# Altium schematic sheet DAQ.SchDoc(DAQ)
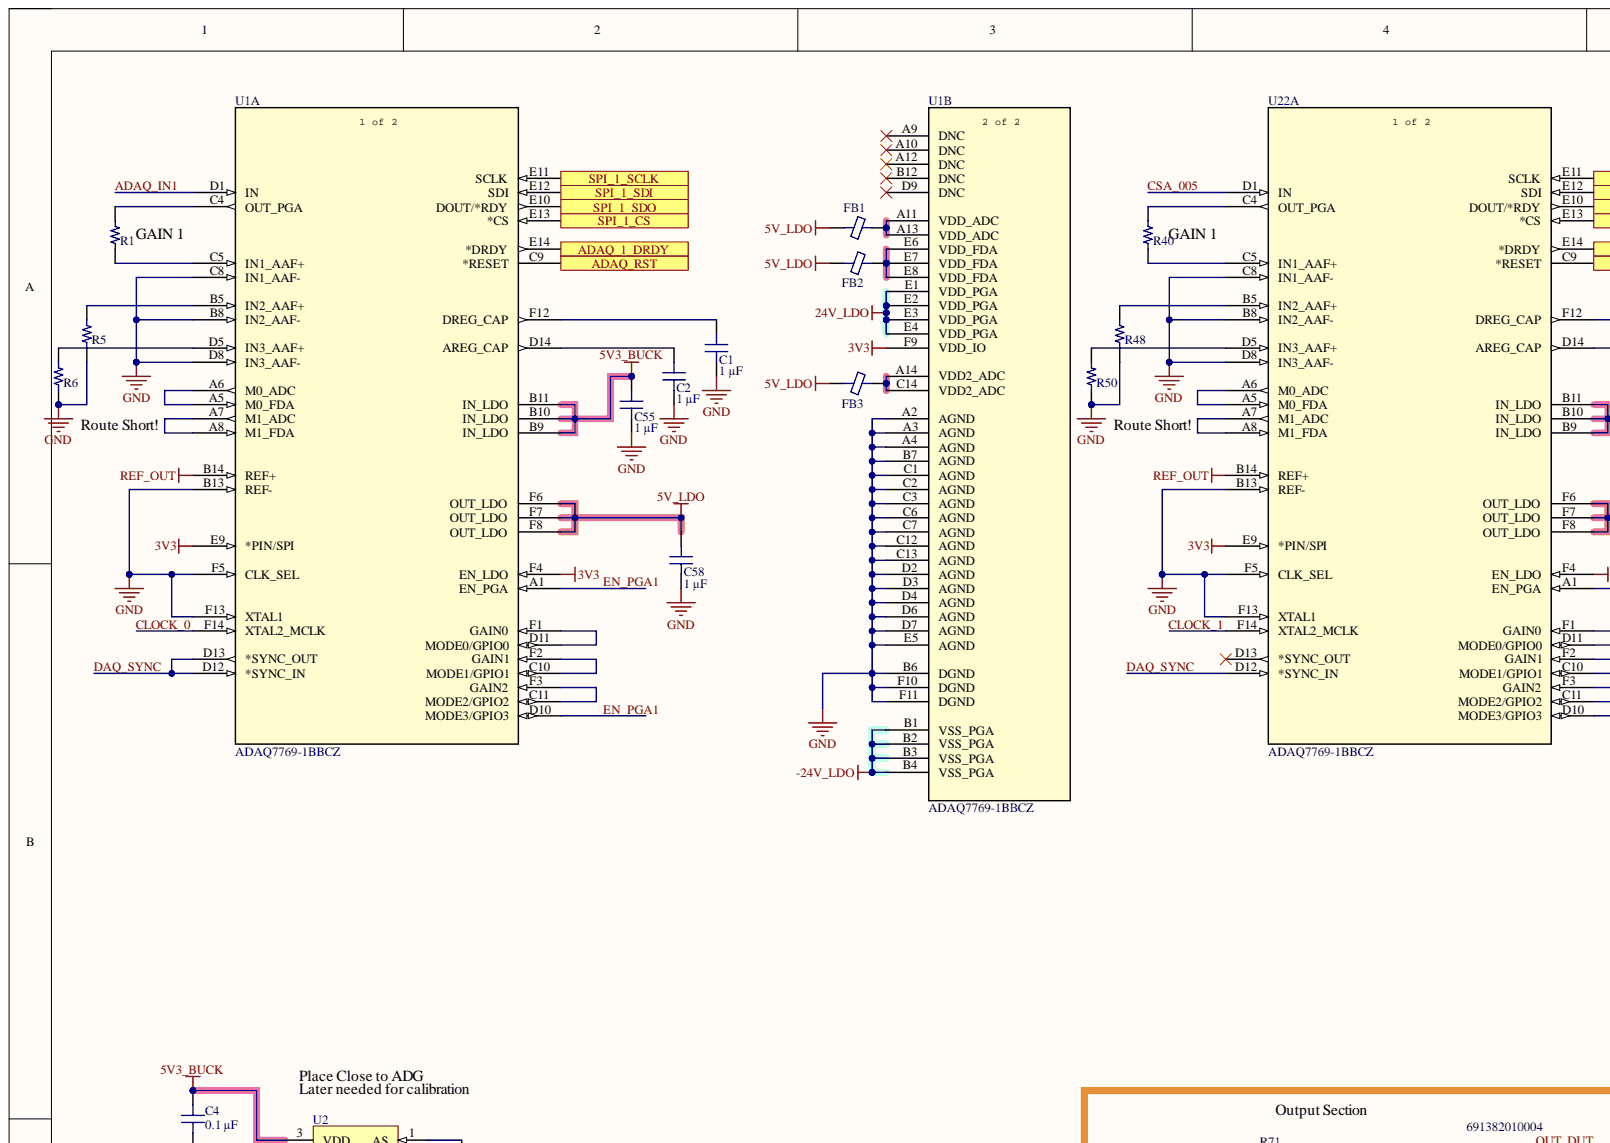
[diagram p0: DAQ.SchDoc, top left]
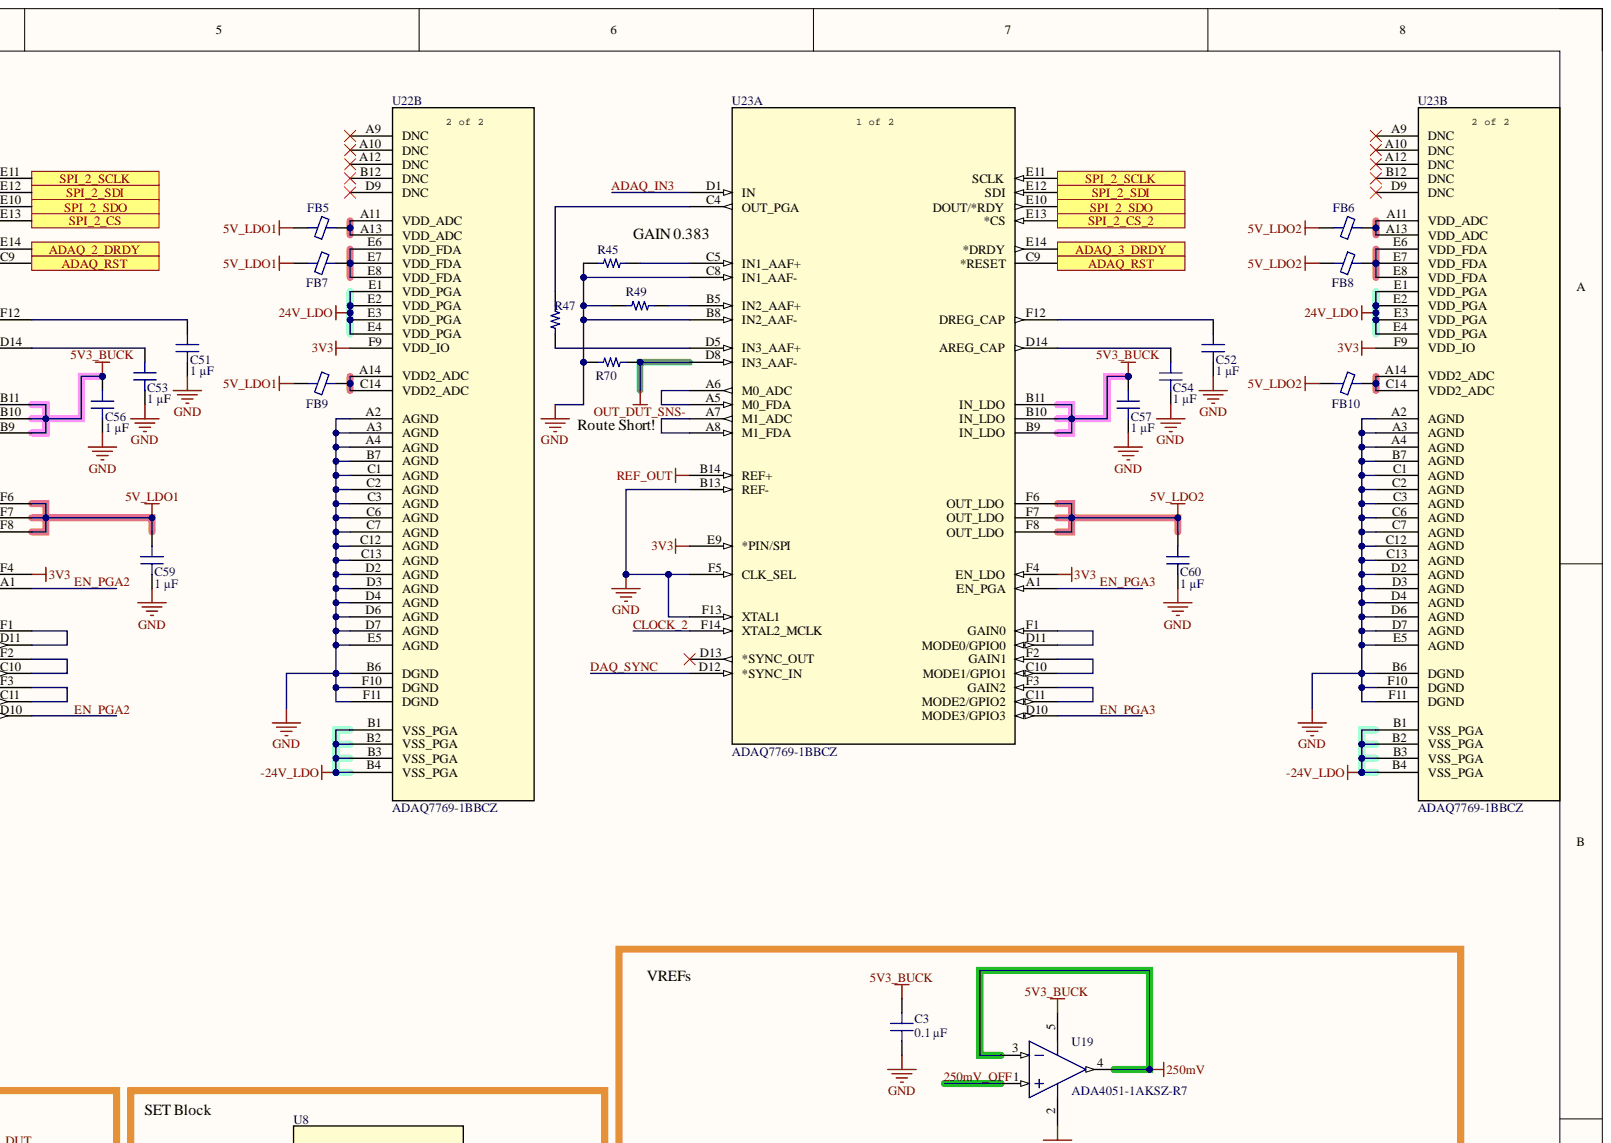
[diagram p1: DAQ.SchDoc, top right]
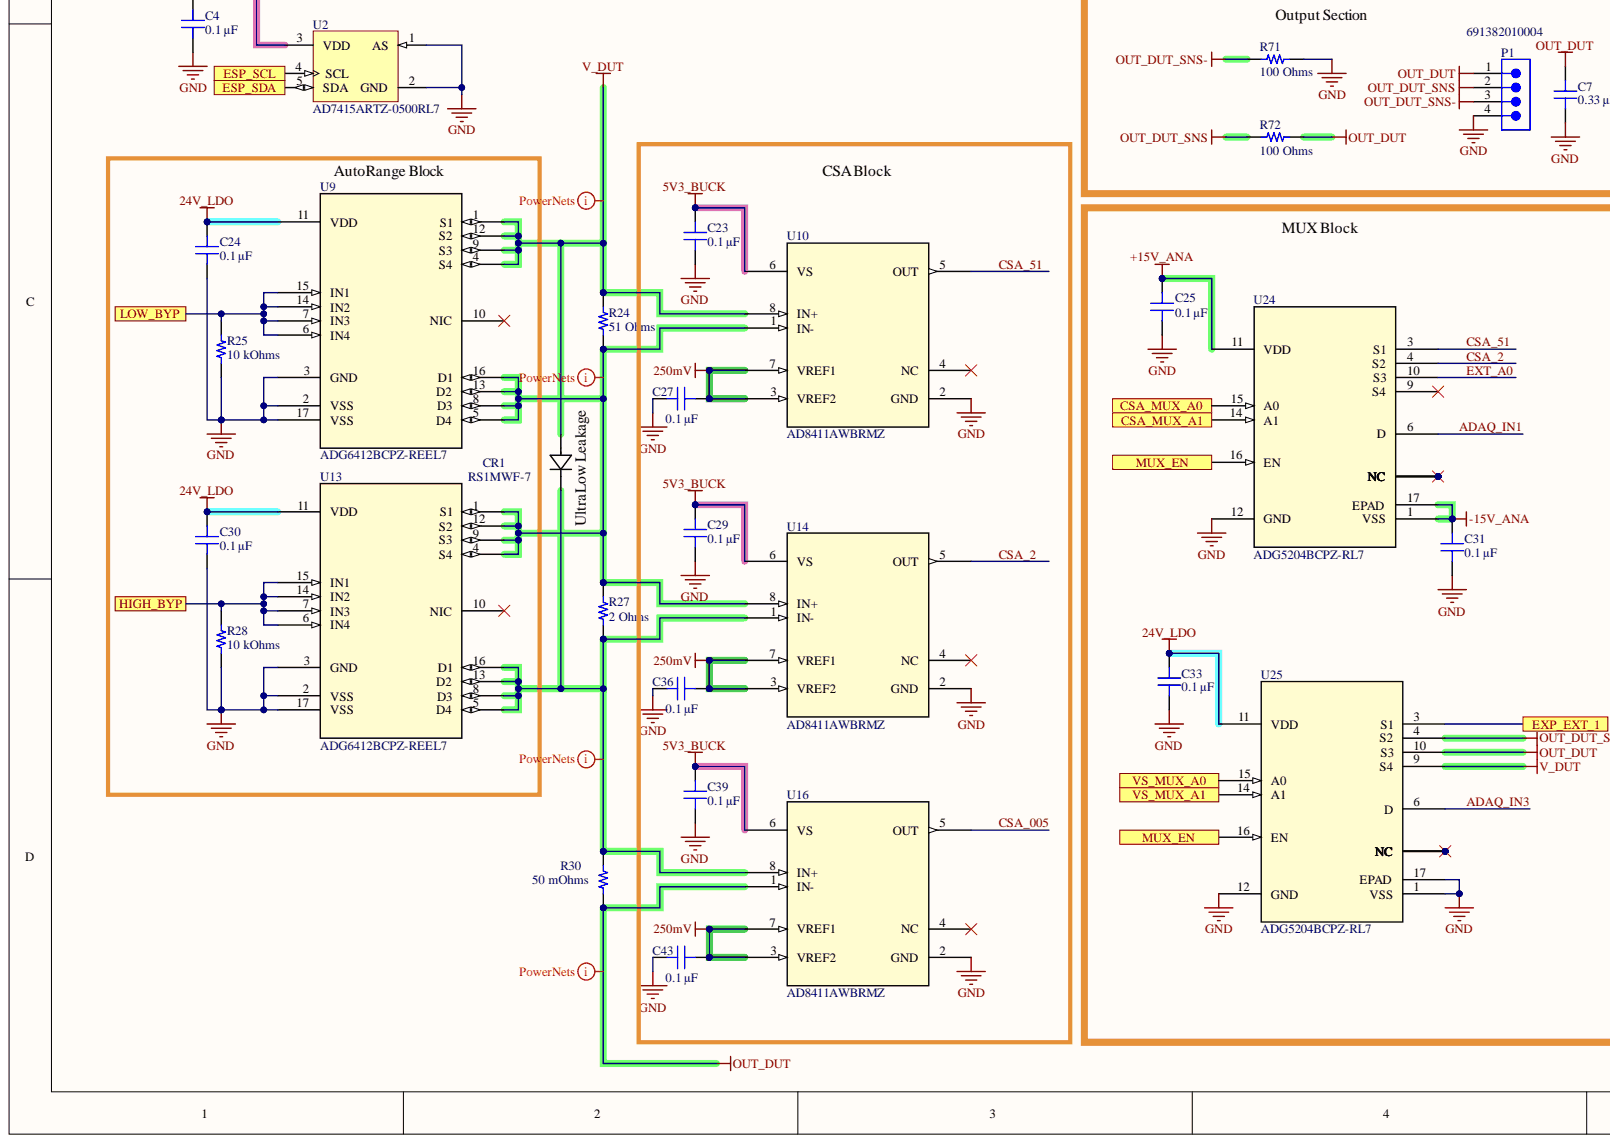
[diagram p2: DAQ.SchDoc, bottom left]
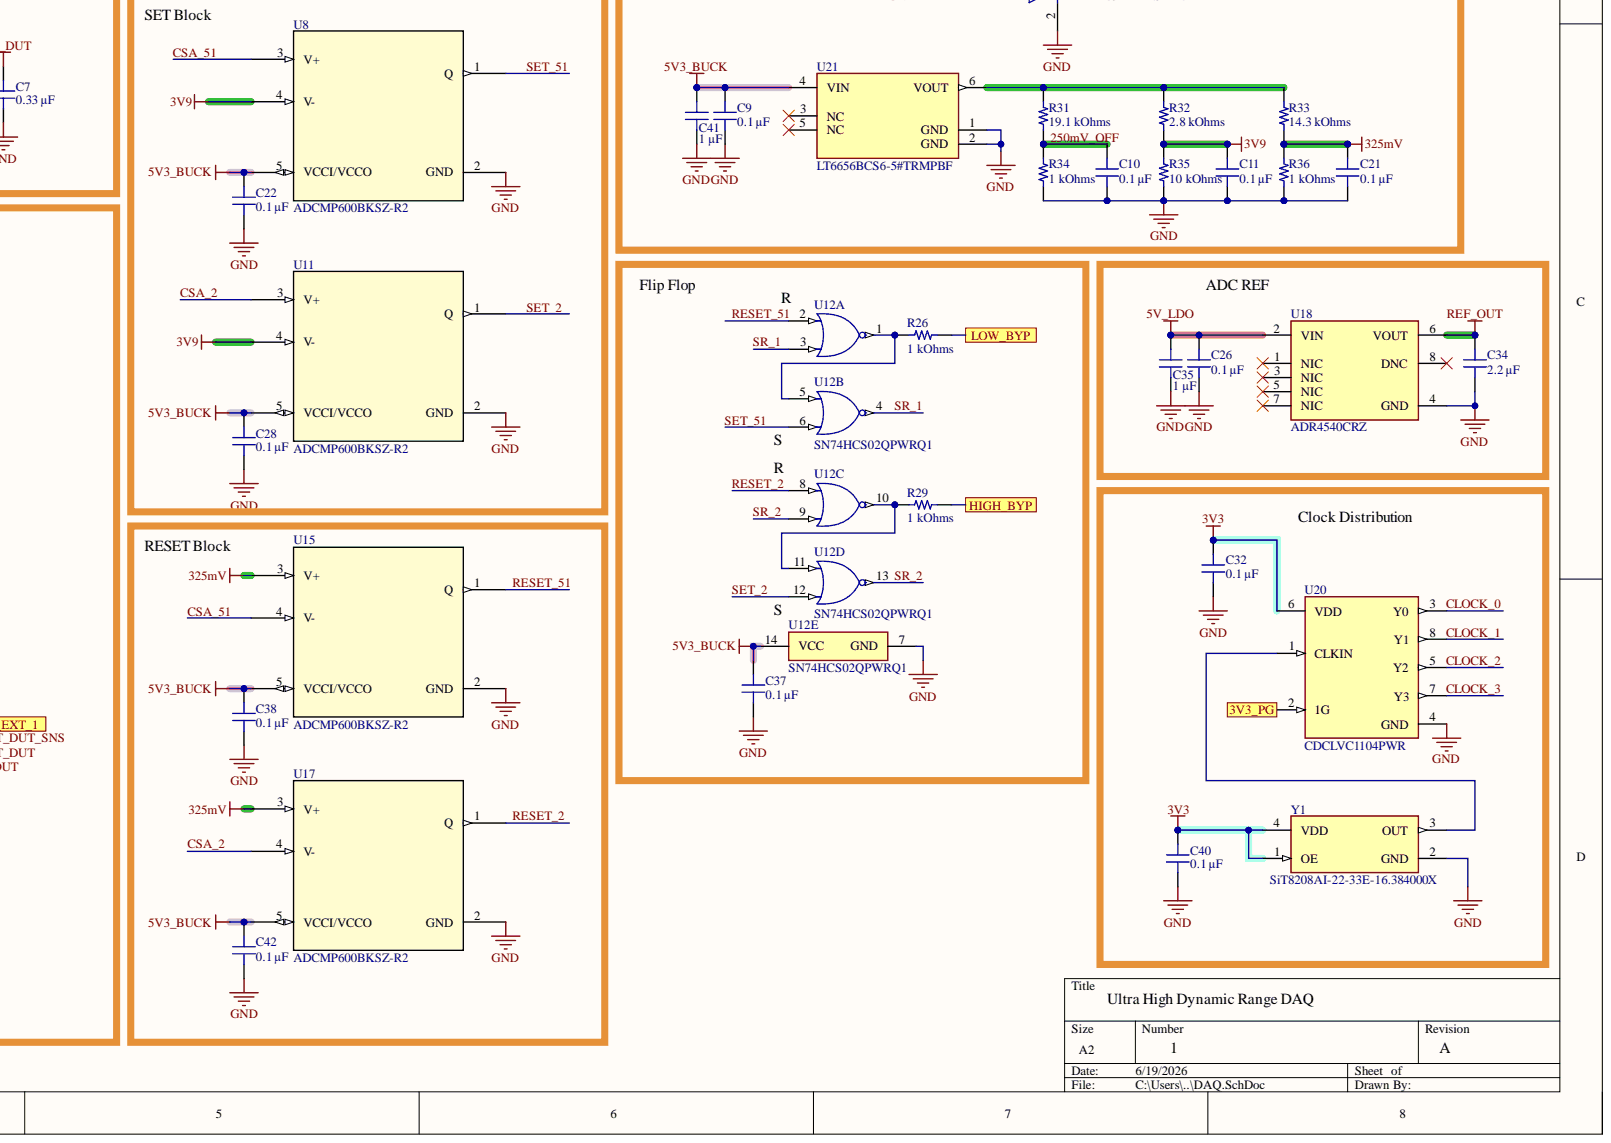
[diagram p3: DAQ.SchDoc, bottom right]

## Parts
C1  1 µF  [CAP 0603_1608]
C2  1 µF  [CAP 0603_1608]
C3  0.1 µF  [CAP 0603_1608]
C4  0.1 µF  [CAP 0603_1608]
C7  0.33 µF  [CAP 1206_3216 - 0.8MM]
C9  0.1 µF  [CAP 0603_1608]
C10  0.1 µF  [CAP 0603_1608]
C11  0.1 µF  [CAP 0603_1608]
C21  0.1 µF  [CAP 0603_1608]
C22  0.1 µF  [CAP 0603_1608]
C23  0.1 µF  [CAP 0603_1608]
C24  0.1 µF  [CAP 0603_1608]
C25  0.1 µF  [CAP 0603_1608]
C26  0.1 µF  [CAP 0603_1608]
C27  0.1 µF  [CAP 0603_1608]
C28  0.1 µF  [CAP 0603_1608]
C29  0.1 µF  [CAP 0603_1608]
C30  0.1 µF  [CAP 0603_1608]
C31  0.1 µF  [CAP 0603_1608]
C32  0.1 µF  [CAP 0603_1608]
C33  0.1 µF  [CAP 0603_1608]
C34  2.2 µF  [CAP 0805_2012]
C35  1 µF  [CAP 0603_1608]
C36  0.1 µF  [CAP 0603_1608]
C37  0.1 µF  [CAP 0603_1608]
C38  0.1 µF  [CAP 0603_1608]
C39  0.1 µF  [CAP 0603_1608]
C40  0.1 µF  [CAP 0603_1608]
C41  1 µF  [CAP 0603_1608]
C42  0.1 µF  [CAP 0603_1608]
C43  0.1 µF  [CAP 0603_1608]
C51  1 µF  [CAP 0603_1608]
C52  1 µF  [CAP 0603_1608]
C53  1 µF  [CAP 0603_1608]
C54  1 µF  [CAP 0603_1608]
C55  1 µF  [CAP 0603_1608]
C56  1 µF  [CAP 0603_1608]
C57  1 µF  [CAP 0603_1608]
C58  1 µF  [CAP 0603_1608]
C59  1 µF  [CAP 0603_1608]
C60  1 µF  [CAP 0603_1608]
CR1  RS1MWF-7  [SOD123F_RS1MWF_DIO]
FB1  1000 Ohms @ 100 MHz  [FER 0603_1608]  (value not drawn)
FB2  1000 Ohms @ 100 MHz  [FER 0603_1608]  (value not drawn)
FB3  1000 Ohms @ 100 MHz  [FER 0603_1608]  (value not drawn)
FB5  1000 Ohms @ 100 MHz  [FER 0603_1608]  (value not drawn)
FB6  1000 Ohms @ 100 MHz  [FER 0603_1608]  (value not drawn)
FB7  1000 Ohms @ 100 MHz  [FER 0603_1608]  (value not drawn)
FB8  1000 Ohms @ 100 MHz  [FER 0603_1608]  (value not drawn)
FB9  1000 Ohms @ 100 MHz  [FER 0603_1608]  (value not drawn)
FB10  1000 Ohms @ 100 MHz  [FER 0603_1608]  (value not drawn)
P1  691382010004  [691382010004]
R1  0 Ohms  [RES 0603_1608]  (value not drawn)
R5  0 Ohms  [RES 0603_1608]  (value not drawn)
R6  0 Ohms  [RES 0603_1608]  (value not drawn)
R24  51 Ohms  [STACKPOLE CSR2512]
R25  10 kOhms  [RES 0603_1608]
R26  1 kOhms  [RES 0603_1608]
R27  2 Ohms  [STACKPOLE CSR2512]
R28  10 kOhms  [RES 0603_1608]
R29  1 kOhms  [RES 0603_1608]
R30  50 mOhms  [TE TLRP 2512]
R31  19.1 kOhms  [RES 0603_1608]
R32  2.8 kOhms  [RES 0603_1608]
R33  14.3 kOhms  [RES 0603_1608]
R34  1 kOhms  [RES 0603_1608]
R35  10 kOhms  [RES 0603_1608]
R36  1 kOhms  [RES 0603_1608]
R40  0 Ohms  [RES 0603_1608]  (value not drawn)
R45  0 Ohms  [RES 0603_1608]  (value not drawn)
R47  0 Ohms  [RES 0603_1608]  (value not drawn)
R48  0 Ohms  [RES 0603_1608]  (value not drawn)
R49  0 Ohms  [RES 0603_1608]  (value not drawn)
R50  0 Ohms  [RES 0603_1608]  (value not drawn)
R70  0 Ohms  [RES 0603_1608]  (value not drawn)
R71  100 Ohms  [RES 0603_1608]
R72  100 Ohms  [RES 0603_1608]
U1  ADAQ7769-1BBCZ  [BC-84-4_ADI]  (parts A,B)
U2  AD7415ARTZ-0500RL7  [ADI-RJ-5_M]
U8  ADCMP600BKSZ-R2  [ADI-KS-5_L]
U9  ADG6412BCPZ-REEL7  [CP_16_17_ADI]
U10  AD8411AWBRMZ  [RM-8_ADI]
U11  ADCMP600BKSZ-R2  [ADI-KS-5_L]
U12  SN74HCS02QPWRQ1  [FP-PW0014A-IPC_B]  (parts A,B,C,D,E)
U13  ADG6412BCPZ-REEL7  [CP_16_17_ADI]
U14  AD8411AWBRMZ  [RM-8_ADI]
U15  ADCMP600BKSZ-R2  [ADI-KS-5_L]
U16  AD8411AWBRMZ  [RM-8_ADI]
U17  ADCMP600BKSZ-R2  [ADI-KS-5_L]
U18  ADR4540CRZ  [FP-R-8-IPC_C]
U19  ADA4051-1AKSZ-R7  [ADI-KS-5_M]
U20  CDCLVC1104PWR  [FP-PW0008A-MFG]
U21  LT6656BCS6-5#TRMPBF  [FP-S6-6-05-08-1636-IPC_B]
U22  ADAQ7769-1BBCZ  [BC-84-4_ADI]  (parts A,B)
U23  ADAQ7769-1BBCZ  [BC-84-4_ADI]  (parts A,B)
U24  ADG5204BCPZ-RL7  [CP_16_17_ADI]
U25  ADG5204BCPZ-RL7  [CP_16_17_ADI]
Y1  SiT8208AI-22-33E-16.384000X  [FP-SiT8208-2-MFG]

## Ports
3V3_PG, ADAQ_1_DRDY, ADAQ_2_DRDY, ADAQ_3_DRDY, ADAQ_RST, CSA_MUX_A0, CSA_MUX_A1, ESP_SCL, ESP_SDA, EXP_EXT_1, HIGH_BYP, LOW_BYP, MUX_EN, SPI_1_CS, SPI_1_SCLK, SPI_1_SDI, SPI_1_SDO, SPI_2_CS, SPI_2_CS_2, SPI_2_SCLK, SPI_2_SDI, SPI_2_SDO, VS_MUX_A0, VS_MUX_A1

## Nets
3V3: C32.2 C40.2 U1.E9(*PIN/SPI) U1.F4(EN_LDO) U1.F9(VDD_IO) U20.6(VDD) U22.E9(*PIN/SPI) U22.F4(EN_LDO) U22.F9(VDD_IO) U23.E9(*PIN/SPI) U23.F4(EN_LDO) U23.F9(VDD_IO) Y1.1(OE) Y1.4(VDD)
3V9: C11.2 R32.1 R35.2 U8.4(V-) U11.4(V-)
5V3_BUCK: C3.2 C4.2 C9.2 C22.2 C23.2 C28.2 C29.2 C37.2 C38.2 C39.2 C41.2 C42.2 C55.2 C56.2 C57.2 U1.B9(IN_LDO) U1.B10(IN_LDO) U1.B11(IN_LDO) U2.3(VDD) U8.5(VCCI/VCCO) U10.6(VS) U11.5(VCCI/VCCO) U12.14(VCC) U14.6(VS) U15.5(VCCI/VCCO) U16.6(VS) U17.5(VCCI/VCCO) U19.5 U21.4(VIN) U22.B9(IN_LDO) U22.B10(IN_LDO) U22.B11(IN_LDO) U23.B9(IN_LDO) U23.B10(IN_LDO) U23.B11(IN_LDO)
5V_LDO: C26.2 C35.2 C58.2 FB1.1 FB2.1 FB3.1 U1.F6(OUT_LDO) U1.F7(OUT_LDO) U1.F8(OUT_LDO) U18.2(VIN)
5V_LDO1: C59.2 FB5.1 FB7.1 FB9.1 U22.F6(OUT_LDO) U22.F7(OUT_LDO) U22.F8(OUT_LDO)
5V_LDO2: C60.2 FB6.1 FB8.1 FB10.1 U23.F6(OUT_LDO) U23.F7(OUT_LDO) U23.F8(OUT_LDO)
24V_LDO: C24.2 C30.2 C33.2 U1.E1(VDD_PGA) U1.E2(VDD_PGA) U1.E3(VDD_PGA) U1.E4(VDD_PGA) U9.11(VDD) U13.11(VDD) U22.E1(VDD_PGA) U22.E2(VDD_PGA) U22.E3(VDD_PGA) U22.E4(VDD_PGA) U23.E1(VDD_PGA) U23.E2(VDD_PGA) U23.E3(VDD_PGA) U23.E4(VDD_PGA) U25.11(VDD)
250mV: C27.1 C36.1 C43.1 U10.3(VREF2) U10.7(VREF1) U14.3(VREF2) U14.7(VREF1) U16.3(VREF2) U16.7(VREF1) U19.3 U19.4
325mV: C21.2 R33.1 R36.2 U15.3(V+) U17.3(V+)
+15V_ANA: C25.2 U24.11(VDD)
-15V_ANA: C31.2 U24.1(VSS) U24.17(EPAD)
-24V_LDO: U1.B1(VSS_PGA) U1.B2(VSS_PGA) U1.B3(VSS_PGA) U1.B4(VSS_PGA) U22.B1(VSS_PGA) U22.B2(VSS_PGA) U22.B3(VSS_PGA) U22.B4(VSS_PGA) U23.B1(VSS_PGA) U23.B2(VSS_PGA) U23.B3(VSS_PGA) U23.B4(VSS_PGA)
GND: C1.1 C2.1 C3.1 C4.1 C7.1 C9.1 C10.1 C11.1 C21.1 C22.1 C23.1 C24.1 C25.1 C26.1 C27.2 C28.1 C29.1 C30.1 C31.1 C32.1 C33.1 C34.1 C35.1 C36.2 C37.1 C38.1 C39.1 C40.1 C41.1 C42.1 C43.2 C51.1 C52.1 C53.1 C54.1 C55.1 C56.1 C57.1 C58.1 C59.1 C60.1 P1.4 R5.1 R6.1 R25.1 R28.1 R34.1 R35.1 R36.1 R45.1 R48.1 R49.2 R50.1 R70.2 R71.2 U1.A2(AGND) U1.A3(AGND) U1.A4(AGND) U1.B6(DGND) U1.B7(AGND) U1.B8(IN2_AAF-) U1.B13(REF-) U1.C1(AGND) U1.C2(AGND) U1.C3(AGND) U1.C6(AGND) U1.C7(AGND) U1.C8(IN1_AAF-) U1.C12(AGND) U1.C13(AGND) U1.D2(AGND) U1.D3(AGND) U1.D4(AGND) U1.D6(AGND) U1.D7(AGND) U1.D8(IN3_AAF-) U1.E5(AGND) U1.F5(CLK_SEL) U1.F10(DGND) U1.F11(DGND) U1.F13(XTAL1) U2.1(AS) U2.2(GND) U8.2(GND) U9.2(VSS) U9.3(GND) U9.17(VSS) U10.2(GND) U11.2(GND) U12.7(GND) U13.2(VSS) U13.3(GND) U13.17(VSS) U14.2(GND) U15.2(GND) U16.2(GND) U17.2(GND) U18.4(GND) U19.2 U20.4(GND) U21.1(GND) U21.2(GND) U22.A2(AGND) U22.A3(AGND) U22.A4(AGND) U22.B6(DGND) U22.B7(AGND) U22.B8(IN2_AAF-) U22.B13(REF-) U22.C1(AGND) U22.C2(AGND) U22.C3(AGND) U22.C6(AGND) U22.C7(AGND) U22.C8(IN1_AAF-) U22.C12(AGND) U22.C13(AGND) U22.D2(AGND) U22.D3(AGND) U22.D4(AGND) U22.D6(AGND) U22.D7(AGND) U22.D8(IN3_AAF-) U22.E5(AGND) U22.F5(CLK_SEL) U22.F10(DGND) U22.F11(DGND) U22.F13(XTAL1) U23.A2(AGND) U23.A3(AGND) U23.A4(AGND) U23.B6(DGND) U23.B7(AGND) U23.B8(IN2_AAF-) U23.B13(REF-) U23.C1(AGND) U23.C2(AGND) U23.C3(AGND) U23.C6(AGND) U23.C7(AGND) U23.C8(IN1_AAF-) U23.C12(AGND) U23.C13(AGND) U23.D2(AGND) U23.D3(AGND) U23.D4(AGND) U23.D6(AGND) U23.D7(AGND) U23.E5(AGND) U23.F5(CLK_SEL) U23.F10(DGND) U23.F11(DGND) U23.F13(XTAL1) U24.12(GND) U25.1(VSS) U25.12(GND) U25.17(EPAD) Y1.2(GND)
OUT_DUT: C7.2 P1.1 R30.1 R72.2 U16.1(IN-) U25.10(S3)
OUT_DUT_SNS: P1.2 R72.1 U25.4(S2)
OUT_DUT_SNS-: P1.3 R70.1 R71.1 U23.D8(IN3_AAF-)
REF_OUT: C34.2 U1.B14(REF+) U18.6(VOUT) U22.B14(REF+) U23.B14(REF+)
V_DUT: CR1.2 R24.2 U9.1(S1) U9.4(S4) U9.9(S3) U9.12(S2) U10.8(IN+) U25.9(S4)
3V3_PG: U20.2(1G) ; port 3V3_PG
250mV_OFF: C10.2 R31.1 R34.2 U19.1
ADAQ_1_DRDY: U1.E14(*DRDY) ; port ADAQ_1_DRDY
ADAQ_2_DRDY: U22.E14(*DRDY) ; port ADAQ_2_DRDY
ADAQ_3_DRDY: U23.E14(*DRDY) ; port ADAQ_3_DRDY
ADAQ_IN1: U1.D1(IN) U24.6(D)
ADAQ_IN3: U23.D1(IN) U25.6(D)
ADAQ_RST: U1.C9(*RESET) U22.C9(*RESET) U23.C9(*RESET) ; port ADAQ_RST
CLOCK_0: U1.F14(XTAL2_MCLK) U20.3(Y0)
CLOCK_1: U20.8(Y1) U22.F14(XTAL2_MCLK)
CLOCK_2: U20.5(Y2) U23.F14(XTAL2_MCLK)
CLOCK_3: U20.7(Y3)
CSA_2: U11.3(V+) U14.5(OUT) U17.4(V-) U24.4(S2)
CSA_005: U16.5(OUT) U22.D1(IN)
CSA_51: U8.3(V+) U10.5(OUT) U15.4(V-) U24.3(S1)
CSA_MUX_A0: U24.15(A0) ; port CSA_MUX_A0
CSA_MUX_A1: U24.14(A1) ; port CSA_MUX_A1
DAQ_SYNC: U1.D12(*SYNC_IN) U1.D13(*SYNC_OUT) U22.D12(*SYNC_IN) U23.D12(*SYNC_IN)
EN_PGA1: U1.A1(EN_PGA) U1.D10(MODE3/GPIO3)
EN_PGA2: U22.A1(EN_PGA) U22.D10(MODE3/GPIO3)
EN_PGA3: U23.A1(EN_PGA) U23.D10(MODE3/GPIO3)
ESP_SCL: U2.4(SCL) ; port ESP_SCL
ESP_SDA: U2.5(SDA) ; port ESP_SDA
EXP_EXT_1: U25.3(S1) ; port EXP_EXT_1
EXT_A0: U24.10(S3)
HIGH_BYP: R28.2 R29.1 U13.6(IN4) U13.7(IN3) U13.14(IN2) U13.15(IN1) ; port HIGH_BYP
LOW_BYP: R25.2 R26.1 U9.6(IN4) U9.7(IN3) U9.14(IN2) U9.15(IN1) ; port LOW_BYP
MUX_EN: U24.16(EN) U25.16(EN) ; port MUX_EN
RESET_2: U12.8 U17.1(Q)
RESET_51: U12.2 U15.1(Q)
SET_2: U11.1(Q) U12.12
SET_51: U8.1(Q) U12.6
SPI_1_CS: U1.E13(*CS) ; port SPI_1_CS
SPI_1_SCLK: U1.E11(SCLK) ; port SPI_1_SCLK
SPI_1_SDI: U1.E12(SDI) ; port SPI_1_SDI
SPI_1_SDO: U1.E10(DOUT/*RDY) ; port SPI_1_SDO
SPI_2_CS: U22.E13(*CS) ; port SPI_2_CS
SPI_2_CS_2: U23.E13(*CS) ; port SPI_2_CS_2
SPI_2_SCLK: U22.E11(SCLK) U23.E11(SCLK) ; port SPI_2_SCLK
SPI_2_SDI: U22.E12(SDI) U23.E12(SDI) ; port SPI_2_SDI
SPI_2_SDO: U22.E10(DOUT/*RDY) U23.E10(DOUT/*RDY) ; port SPI_2_SDO
SR_1: U12.3 U12.4
SR_2: U12.9 U12.13
VS_MUX_A0: U25.15(A0) ; port VS_MUX_A0
VS_MUX_A1: U25.14(A1) ; port VS_MUX_A1
NetC1_2: C1.2 U1.F12(DREG_CAP)
NetC2_2: C2.2 U1.D14(AREG_CAP)
NetC51_2: C51.2 U22.F12(DREG_CAP)
NetC52_2: C52.2 U23.F12(DREG_CAP)
NetC53_2: C53.2 U22.D14(AREG_CAP)
NetC54_2: C54.2 U23.D14(AREG_CAP)
NetCR1_1: CR1.1 R27.2 R30.2 U13.5(D4) U13.8(D3) U13.13(D2) U13.16(D1) U14.1(IN-) U16.8(IN+)
NetFB1_2: FB1.2 U1.A11(VDD_ADC) U1.A13(VDD_ADC)
NetFB2_2: FB2.2 U1.E6(VDD_FDA) U1.E7(VDD_FDA) U1.E8(VDD_FDA)
NetFB3_2: FB3.2 U1.A14(VDD2_ADC) U1.C14(VDD2_ADC)
NetFB5_2: FB5.2 U22.A11(VDD_ADC) U22.A13(VDD_ADC)
NetFB6_2: FB6.2 U23.A11(VDD_ADC) U23.A13(VDD_ADC)
NetFB7_2: FB7.2 U22.E6(VDD_FDA) U22.E7(VDD_FDA) U22.E8(VDD_FDA)
NetFB8_2: FB8.2 U23.E6(VDD_FDA) U23.E7(VDD_FDA) U23.E8(VDD_FDA)
NetFB9_2: FB9.2 U22.A14(VDD2_ADC) U22.C14(VDD2_ADC)
NetFB10_2: FB10.2 U23.A14(VDD2_ADC) U23.C14(VDD2_ADC)
NetR1_1: R1.1 U1.C5(IN1_AAF+)
NetR1_2: R1.2 U1.C4(OUT_PGA)
NetR5_2: R5.2 U1.B5(IN2_AAF+)
NetR6_2: R6.2 U1.D5(IN3_AAF+)
NetR24_1: R24.1 R27.1 U9.5(D4) U9.8(D3) U9.13(D2) U9.16(D1) U10.1(IN-) U13.1(S1) U13.4(S4) U13.9(S3) U13.12(S2) U14.8(IN+)
NetR26_2: R26.2 U12.1 U12.5
NetR29_2: R29.2 U12.10 U12.11
NetR31_2: R31.2 R32.2 R33.2 U21.6(VOUT)
NetR40_1: R40.1 U22.C5(IN1_AAF+)
NetR40_2: R40.2 U22.C4(OUT_PGA)
NetR45_2: R45.2 U23.C5(IN1_AAF+)
NetR47_1: R47.1 U23.D5(IN3_AAF+)
NetR47_2: R47.2 U23.C4(OUT_PGA)
NetR48_2: R48.2 U22.B5(IN2_AAF+)
NetR49_1: R49.1 U23.B5(IN2_AAF+)
NetR50_2: R50.2 U22.D5(IN3_AAF+)
NetU1_A5: U1.A5(M0_FDA) U1.A6(M0_ADC)
NetU1_A7: U1.A7(M1_ADC) U1.A8(M1_FDA)
NetU1_C10: U1.C10(MODE1/GPIO1) U1.F2(GAIN1)
NetU1_C11: U1.C11(MODE2/GPIO2) U1.F3(GAIN2)
NetU1_D11: U1.D11(MODE0/GPIO0) U1.F1(GAIN0)
NetU20_1: U20.1(CLKIN) Y1.3(OUT)
NetU22_A5: U22.A5(M0_FDA) U22.A6(M0_ADC)
NetU22_A7: U22.A7(M1_ADC) U22.A8(M1_FDA)
NetU22_C10: U22.C10(MODE1/GPIO1) U22.F2(GAIN1)
NetU22_C11: U22.C11(MODE2/GPIO2) U22.F3(GAIN2)
NetU22_D11: U22.D11(MODE0/GPIO0) U22.F1(GAIN0)
NetU23_A5: U23.A5(M0_FDA) U23.A6(M0_ADC)
NetU23_A7: U23.A7(M1_ADC) U23.A8(M1_FDA)
NetU23_C10: U23.C10(MODE1/GPIO1) U23.F2(GAIN1)
NetU23_C11: U23.C11(MODE2/GPIO2) U23.F3(GAIN2)
NetU23_D11: U23.D11(MODE0/GPIO0) U23.F1(GAIN0)
NetU24_2: U24.2(NC) U24.5 U24.7 U24.8 U24.13
NetU25_2: U25.2(NC) U25.5 U25.7 U25.8 U25.13

Not connected: U1 A9(DNC) A10(DNC) A12(DNC) B12(DNC) D9(DNC); U9 10(NIC); U10 4(NC); U13 10(NIC); U14 4(NC); U16 4(NC); U18 1(NIC) 3(NIC) 5(NIC) 7(NIC) 8(DNC); U21 3(NC) 5(NC); U22 A9(DNC) A10(DNC) A12(DNC) B12(DNC) D9(DNC) D13(*SYNC_OUT); U23 A9(DNC) A10(DNC) A12(DNC) B12(DNC) D9(DNC) D13(*SYNC_OUT); U24 9(S4)

## Library data of the parts
C1 C2 C35 C41 C51-C60 = GMC10X7R105M50NT: SMT Capacitor; manufacturer: Cal-Chip Electronics, Inc.; Database Table Name: Capacitors - Ceramic - 0603; Temperature Coefficient: X7R; Tolerance: ±20%; Voltage - Rated: 50V; Applications: General Purpose; Capacitance: 1 µF; Component Kind: Standard; componentlink1description: Datasheet; componentlink1url: https://calchip.com/wp-content/uploads/2024/02/gmc_series-1.pdf; componentlink2description: DigiKey Link; componentlink2url: https://www.digikey.com/en/products/detail/cal-chip-electronics-inc/GMC10X7R105M50NT/25323876; Device Package: 0603 (1608 Metric); lastupdated: 06/04/2026 19:17:52; Library Name: Altium DB.DbLib; Lifecycle Status: Active; Minimum Order: 1; Mounting Type: Surface Mount, MLCC; Operating Temperature: -55°C ~ 125°C; Package / Case: 0603 (1608 Metric); packaging: TapeAndReel; Part Number: GMC10X7R105M50NT; partid: 65934; price: 0.06; series: GMC; Size / Dimension: 0.063" L x 0.031" W (1.60mm x 0.80mm); Supplier 1: DigiKey; Supplier Part Number 1: 4713-GMC10X7R105M50NTCT-ND; Thickness (Max): 0.037" (0.95mm); value: 1 µF
C3 C4 C9-C11 C21-C33 C36-C40 C42 C43 = C0603C104M5RACTU: SMT Capacitor; manufacturer: KEMET; Database Table Name: Capacitors - Ceramic - 0603; Temperature Coefficient: X7R; Tolerance: ±20%; Voltage - Rated: 50V; Applications: Bypass, Decoupling; Capacitance: 0.1 µF; Component Kind: Standard; componentlink1description: Datasheet; componentlink1url: https://api.kemet.com/component-edge/download/datasheet/C0603C104M5RACTU.pdf; componentlink2description: DigiKey Link; componentlink2url: https://www.digikey.com/en/products/detail/kemet/C0603C104M5RACTU/2199782; Device Package: 0603 (1608 Metric); lastupdated: 06/04/2026 19:22:47; Library Name: Altium DB.DbLib; Lifecycle Status: Active; Minimum Order: 1; Mounting Type: Surface Mount, MLCC; Operating Temperature: -55°C ~ 125°C; Package / Case: 0603 (1608 Metric); packaging: CutTape; Part Number: C0603C104M5RACTU; Part Status: Active; partid: 69106; price: 0.01; series: SMD Comm X7R; Size / Dimension: 0.063" L x 0.031" W (1.60mm x 0.80mm); Supplier 1: DigiKey; Supplier Part Number 1: 399-C0603C104M5RACTUCT-ND; Thickness (Max): 0.034" (0.87mm); value: 0.1 µF
C7 = CGA5L3X8R2A334K160AB: SMT Capacitor; manufacturer: TDK Corporation; Database Table Name: Capacitors - Ceramic - 1206; Temperature Coefficient: X8R; Tolerance: ±10%; Voltage - Rated: 100V; Applications: Automotive; Capacitance: 0.33 µF; Component Kind: Standard; componentlink1description: Datasheet; componentlink1url: https://product.tdk.com/info/en/catalog/datasheets/mlcc_automotive_hightemp_en.pdf?ref_disty=digikey; componentlink2description: DigiKey Link; componentlink2url: https://www.digikey.com/en/products/detail/tdk-corporation/CGA5L3X8R2A334K160AB/3950213; Device Package: 1206 (3216 Metric); Features: High Temperature; lastupdated: 06/04/2026 19:37:59; Library Name: Altium DB.DbLib; Lifecycle Status: Active; Minimum Order: 1; Mounting Type: Surface Mount, MLCC; Operating Temperature: -55°C ~ 150°C; Package / Case: 1206 (3216 Metric); packaging: TapeAndReel; Part Number: CGA5L3X8R2A334K160AB; Part Status: Active; partid: 19758; price: 0.15; Ratings: AEC-Q200; series: CGA; Size / Dimension: 0.126" L x 0.063" W (3.20mm x 1.60mm); Supplier 1: DigiKey; Supplier Part Number 1: 445-12977-1-ND; Thickness (Max): 0.071" (1.80mm); value: 0.33 µF
C34 = GMC21X7R225M35NT: SMT Capacitor; manufacturer: Cal-Chip Electronics, Inc.; Database Table Name: Capacitors - Ceramic - 0805; Temperature Coefficient: X7R; Tolerance: ±20%; Voltage - Rated: 35V; Applications: General Purpose; Capacitance: 2.2 µF; Component Kind: Standard; componentlink1description: Datasheet; componentlink1url: https://calchip.com/wp-content/uploads/2024/02/gmc_series-1.pdf; componentlink2description: DigiKey Link; componentlink2url: https://www.digikey.com/en/products/detail/cal-chip-electronics-inc/GMC21X7R225M35NT/25803563; Device Package: 0805 (2012 Metric); lastupdated: 06/04/2026 19:28:46; Library Name: Altium DB.DbLib; Lifecycle Status: Active; Minimum Order: 1; Mounting Type: Surface Mount, MLCC; Operating Temperature: -55°C ~ 125°C; Package / Case: 0805 (2012 Metric); packaging: TapeAndReel; Part Number: GMC21X7R225M35NT; partid: 69969; price: 0.14; series: GMC; Size / Dimension: 0.079" L x 0.049" W (2.00mm x 1.25mm); Supplier 1: DigiKey; Supplier Part Number 1: 4713-GMC21X7R225M35NTCT-ND; Thickness (Max): 0.057" (1.45mm); value: 2.2 µF
CR1 = RS1MWF-7: DIODE STANDARD 1000V 1A SOD123F; Manufacturer_Name: Diodes Inc; Component Kind: Standard; Library Name: Lib.IntLib; Manufacturer_Part_Number: RS1MWF-7; Copyright: Copyright (C) 2026 Ultra Librarian. All rights reserved.
FB1-FB3 FB5-FB10 = WLBD1608HCU102TH: FERRITE BEAD 1000 OHM 0603 1LN; Manufacturer: Walsin Technology Corporation; Current Rating (Max): 1.3A; Database Table Name: Filters - Ferrite Beads and Chips; DC Resistance (DCR) (Max): 150mOhm; Number of Lines: 1; Component Kind: Standard; ComponentLink1Description: Datasheet; ComponentLink1URL: https://www.passivecomponent.com/wp-content/uploads/inductor/WLBD1005-4532_HC_Series.pdf; ComponentLink2Description: DigiKey Link; ComponentLink2URL: https://www.digikey.com/en/products/detail/walsin-technology-corporation/WLBD1608HCU102TH/14563787; Device Package: 0603 (1608 Metric); Height (Max): 0.026" (0.65mm); Impedance @ Frequency: 1000 Ohms @ 100 MHz; LastUpdated: 05/04/2026 20:27:58; Library Name: Altium DB.DbLib; Lifecycle Status: Active; Minimum Order: 1; Mounting Type: Surface Mount; Operating Temperature: -55°C ~ 125°C; Package / Case: 0603 (1608 Metric); Packaging: TapeAndReel; Part Number: WLBD1608HCU102TH; Part Status: Active; PartId: 2833; Price: 0.01; Series: -; Size / Dimension: 0.047" L x 0.025" W (1.19mm x 0.64mm); Supplier 1: DigiKey; Supplier Part Number 1: 1292-WLBD1608HCU102THCT-ND; Value: 1000 Ohms @ 100 MHz
P1 = CMP-1502-01745-3: Terminal Block, R/A Header, 4Pos, Th Rohs Compliant: Yes; Current: 12A; Gender: Male; Number of Levels: 1; Number of Positions: 4; Pitch: 2.4892mm; Voltage: 300V; Color: Green; Component Kind: Standard; Contact Plating: Tin; Lead Pitch: 2.5mm; Library Name: Altium Content Vault; Max Operating Temperature: 105°C; Min Operating Temperature: -40°C; Mount: Through Hole; Number of Pins: 4; Number of Positions Per Level: 4; Packaging: Box; RoHS: Compliant; Termination: Solder
R1 R5 R6 R40 R45 R47-R50 R70 = WSL060300000ZEA9: RES 0 OHM JUMPER 0603; Manufacturer: Vishay Dale; Database Table Name: Resistors - Surface Mount - 0603; Temperature Coefficient: ±3900ppm/°C; Component Kind: Standard; ComponentLink1Description: Datasheet; ComponentLink1URL: http://www.vishay.com/docs/30192/wsl-9.pdf; ComponentLink2Description: DigiKey Link; ComponentLink2URL: https://www.digikey.com/en/products/detail/vishay-dale/WSL060300000ZEA9/7423217; Composition: Metal Element; Device Package: 0603 (1608 Metric); Height - Seated (Max): 0.021" (0.53mm); LastUpdated: 09/02/2024 20:43:09; Library Name: Altium DB.DbLib; Lifecycle Status: Active; Minimum Order: 1; Number of Terminations: 2; Operating Temperature: -65°C ~ 170°C; Package / Case: 0603 (1608 Metric); Packaging: TapeAndReel; Part Number: WSL060300000ZEA9; Part Status: Active; PartId: 11865; Price: 0.39; Resistance: 0 Ohms; Series: WSL; Size / Dimension: 0.060" L x 0.030" W (1.52mm x 0.76mm); Supplier 1: DigiKey; Supplier Device Package: 0603; Supplier Part Number 1: 541-3927-1-ND; Tolerance: Jumper; Value: 0 Ohms
R24 = CRM2512-JW-510ELF: RES SMD 51 OHM 5% 2W 2512; Manufacturer: Bourns Inc.; Database Table Name: Resistors - Current Sense SMT; Temperature Coefficient: ±200ppm/°C; Component Kind: Standard; ComponentLink1Description: Datasheet; ComponentLink1URL: https://www.bourns.com/docs/Product-Datasheets/CRM.pdf; ComponentLink2Description: DigiKey Link; ComponentLink2URL: http://digikey.com/product-detail/en/bourns-inc/CRM2512-JW-510ELF/118-CRM2512-JW-510ELFCT-ND/10673704; Composition: Thick Film; Device Package: 2512 (6432 Metric); Features: Current Sense; Height - Seated (Max): 0.031" (0.80mm); LastUpdated: 02/09/2020 01:18:04; Library Name: Altium DB.DbLib; Lifecycle Status: Active; Minimum Order: 1; Number of Terminations: 2; Operating Temperature: -55°C ~ 155°C; Package / Case: 2512 (6432 Metric); Packaging: TapeAndReel; Part Number: CRM2512-JW-510ELF; Part Status: Active; PartId: 1920; Power (Watts): 2W; Price: 0.06; Resistance: 51 Ohms; Series: CRM2512; Size / Dimension: 0.248" L x 0.122" W (6.30mm x 3.10mm); Supplier 1: DigiKey; Supplier Device Package: 2512; Supplier Part Number 1: 118-CRM2512-JW-510ELFCT-ND; Tolerance: ±5%; Value: 51 Ohms
R25 R28 R35 = ERJ-PA3F1002V: RES SMD 10K OHM 1% 1/3W 0603; Manufacturer: Panasonic Electronic Components; Power (Watts): 0.333W 1/3W; Temperature Coefficient: ±100ppm/°C; Component Kind: Standard; ComponentLink1Description: Datasheet; ComponentLink1URL: https://industrial.panasonic.com/cdbs/www-data/pdf/RDO0000/AOA0000C331.pdf; ComponentLink2Description: DigiKey Link; ComponentLink2URL: https://www.digikey.com/en/products/detail/panasonic-electronic-components/ERJ-PA3F1002V/5035849; Composition: Thick Film; Device Package: 0603 (1608 Metric); Features: Automotive AEC-Q200 Pulse Withstanding; Height - Seated (Max): 0.022\ (0.55mm); LastUpdated: 2024-02-09 20:42:57.847000; Library Name: Altium DB.DbLib; Lifecycle Status: Active; Minimum Order: 1; Number of Terminations: 2; Operating Temperature: -55°C ~ 155°C; Package / Case: 0603 (1608 Metric); Packaging: TapeAndReel; Part Number: ERJ-PA3F1002V; Part Status: Active; PartId: 14698; Price: 0.01; Ratings: AEC-Q200; Resistance: 10 kOhms; Series: ERJ-PA3; Size / Dimension: 0.063\ L x 0.031\ W (1.60mm x 0.80mm); Supplier 1: DigiKey; Supplier Device Package: 0603; Supplier Part Number 1: P10KBYCT-ND; Tolerance: ±1%; Value: 10 kOhms
R26 R29 R34 R36 = ERJ-PA3F1001V: RES SMD 1K OHM 1% 1/3W 0603; Manufacturer: Panasonic Electronic Components; Power (Watts): 0.333W 1/3W; Temperature Coefficient: ±100ppm/°C; Component Kind: Standard; ComponentLink1Description: Datasheet; ComponentLink1URL: https://industrial.panasonic.com/cdbs/www-data/pdf/RDO0000/AOA0000C331.pdf; ComponentLink2Description: DigiKey Link; ComponentLink2URL: https://www.digikey.com/en/products/detail/panasonic-electronic-components/ERJ-PA3F1001V/5035848; Composition: Thick Film; Device Package: 0603 (1608 Metric); Features: Automotive AEC-Q200 Pulse Withstanding; Height - Seated (Max): 0.022\ (0.55mm); LastUpdated: 2024-02-09 20:42:57.220000; Library Name: Altium DB.DbLib; Lifecycle Status: Active; Minimum Order: 1; Number of Terminations: 2; Operating Temperature: -55°C ~ 155°C; Package / Case: 0603 (1608 Metric); Packaging: TapeAndReel; Part Number: ERJ-PA3F1001V; Part Status: Active; PartId: 14885; Price: 0.01; Ratings: AEC-Q200; Resistance: 1 kOhms; Series: ERJ-PA3; Size / Dimension: 0.063\ L x 0.031\ W (1.60mm x 0.80mm); Supplier 1: DigiKey; Supplier Device Package: 0603; Supplier Part Number 1: P1.0KBYCT-ND; Tolerance: ±1%; Value: 1 kOhms
R27 = CRM2512-FX-2R00ELF: RES SMD 2 OHM 1% 2W 2512; Manufacturer: Bourns Inc.; Database Table Name: Resistors - Current Sense SMT; Temperature Coefficient: ±100ppm/°C; Component Kind: Standard; ComponentLink1Description: Datasheet; ComponentLink1URL: https://www.bourns.com/docs/Product-Datasheets/CRM.pdf; ComponentLink2Description: DigiKey Link; ComponentLink2URL: http://digikey.com/product-detail/en/bourns-inc/CRM2512-FX-2R00ELF/CRM2512-FX-2R00ELFCT-ND/9677732; Composition: Thick Film; Device Package: 2512 (6432 Metric); Features: Current Sense; Height - Seated (Max): 0.031" (0.80mm); LastUpdated: 02/09/2020 01:18:05; Library Name: Altium DB.DbLib; Lifecycle Status: Active; Minimum Order: 1; Number of Terminations: 2; Operating Temperature: -55°C ~ 155°C; Package / Case: 2512 (6432 Metric); Packaging: TapeAndReel; Part Number: CRM2512-FX-2R00ELF; Part Status: Active; PartId: 1870; Power (Watts): 2W; Price: 0.10; Resistance: 2 Ohms; Series: CRM2512; Size / Dimension: 0.248" L x 0.122" W (6.30mm x 3.10mm); Supplier 1: DigiKey; Supplier Device Package: 2512; Supplier Part Number 1: CRM2512-FX-2R00ELFCT-ND; Tolerance: ±1%; Value: 2 Ohms
R30 = TLRP3A20WR050FTE: RES 0.05 OHM 1% 2W 2512; Manufacturer: TE Connectivity Passive Product; Database Table Name: Resistors - Current Sense SMT; Temperature Coefficient: ±75ppm/°C; Component Kind: Standard; ComponentLink1Description: Datasheet; ComponentLink1URL: https://www.te.com/commerce/DocumentDelivery/DDEController?Action=srchrtrv&DocNm=1773449-4&DocType=DS&DocLang=English; ComponentLink2Description: DigiKey Link; ComponentLink2URL: https://www.digikey.com/en/products/detail/te-connectivity-passive-product/TLRP3A20WR050FTE/14652078; Composition: Metal Element; Device Package: 2512 (6332 Metric); Features: Anti-Sulfur, Automotive AEC-Q200, Current Sense; Height - Seated (Max): 0.035" (0.90mm); LastUpdated: 05/04/2026 21:05:23; Library Name: Altium DB.DbLib; Lifecycle Status: Active; Minimum Order: 1; Number of Terminations: 2; Operating Temperature: -55°C ~ 170°C; Package / Case: 2512 (6332 Metric); Packaging: TapeAndReel; Part Number: TLRP3A20WR050FTE; Part Status: Active; PartId: 3243; Power (Watts): 2W; Price: 0.14; Ratings: AEC-Q200; Resistance: 50 mOhms; Series: TLRP; Size / Dimension: 0.252" L x 0.126" W (6.40mm x 3.20mm); Supplier 1: DigiKey; Supplier Device Package: 2512; Supplier Part Number 1: 1712-TLRP3A20WR050FTECT-ND; Tolerance: ±1%; Value: 50 mOhms
R31 = ERJ-3EKF1912V: RES SMD 19.1K OHM 1% 1/10W 0603; Manufacturer: Panasonic Electronic Components; Power (Watts): 0.1W 1/10W; Temperature Coefficient: ±100ppm/°C; Component Kind: Standard; ComponentLink1Description: Datasheet; ComponentLink1URL: https://industrial.panasonic.com/cdbs/www-data/pdf/RDA0000/AOA0000C304.pdf; ComponentLink2Description: DigiKey Link; ComponentLink2URL: https://www.digikey.com/en/products/detail/panasonic-electronic-components/ERJ-3EKF1912V/196175; Composition: Thick Film; Device Package: 0603 (1608 Metric); Features: Automotive AEC-Q200; Height - Seated (Max): 0.022\ (0.55mm); LastUpdated: 2024-02-09 20:42:07.760000; Library Name: Altium DB.DbLib; Lifecycle Status: Active; Minimum Order: 1; Number of Terminations: 2; Operating Temperature: -55°C ~ 155°C; Package / Case: 0603 (1608 Metric); Packaging: TapeAndReel; Part Number: ERJ-3EKF1912V; Part Status: Active; PartId: 24830; Price: 0.01; Ratings: AEC-Q200; Resistance: 19.1 kOhms; Series: ERJ-3EK; Size / Dimension: 0.063\ L x 0.031\ W (1.60mm x 0.80mm); Supplier 1: DigiKey; Supplier Device Package: 0603; Supplier Part Number 1: P19.1KHCT-ND; Tolerance: ±1%; Value: 19.1 kOhms
R32 = ERJ-3EKF2801V: RES SMD 2.8K OHM 1% 1/10W 0603; Manufacturer: Panasonic Electronic Components; Power (Watts): 0.1W 1/10W; Temperature Coefficient: ±100ppm/°C; Component Kind: Standard; ComponentLink1Description: Datasheet; ComponentLink1URL: https://industrial.panasonic.com/cdbs/www-data/pdf/RDA0000/AOA0000C304.pdf; ComponentLink2Description: DigiKey Link; ComponentLink2URL: https://www.digikey.com/en/products/detail/panasonic-electronic-components/ERJ-3EKF2801V/196198; Composition: Thick Film; Device Package: 0603 (1608 Metric); Features: Automotive AEC-Q200; Height - Seated (Max): 0.022\ (0.55mm); LastUpdated: 2024-02-09 20:42:22.487000; Library Name: Altium DB.DbLib; Lifecycle Status: Active; Minimum Order: 1; Number of Terminations: 2; Operating Temperature: -55°C ~ 155°C; Package / Case: 0603 (1608 Metric); Packaging: TapeAndReel; Part Number: ERJ-3EKF2801V; Part Status: Active; PartId: 23129; Price: 0.01; Ratings: AEC-Q200; Resistance: 2.8 kOhms; Series: ERJ-3EK; Size / Dimension: 0.063\ L x 0.031\ W (1.60mm x 0.80mm); Supplier 1: DigiKey; Supplier Device Package: 0603; Supplier Part Number 1: P2.80KHCT-ND; Tolerance: ±1%; Value: 2.8 kOhms
R33 = ERJ-3EKF1432V: RES SMD 14.3K OHM 1% 1/10W 0603; Manufacturer: Panasonic Electronic Components; Power (Watts): 0.1W 1/10W; Temperature Coefficient: ±100ppm/°C; Component Kind: Standard; ComponentLink1Description: Datasheet; ComponentLink1URL: https://industrial.panasonic.com/cdbs/www-data/pdf/RDA0000/AOA0000C304.pdf; ComponentLink2Description: DigiKey Link; ComponentLink2URL: https://www.digikey.com/en/products/detail/panasonic-electronic-components/ERJ-3EKF1432V/97438; Composition: Thick Film; Device Package: 0603 (1608 Metric); Features: Automotive AEC-Q200; Height - Seated (Max): 0.022\ (0.55mm); LastUpdated: 2024-02-09 20:41:56.467000; Library Name: Altium DB.DbLib; Lifecycle Status: Active; Minimum Order: 1; Number of Terminations: 2; Operating Temperature: -55°C ~ 155°C; Package / Case: 0603 (1608 Metric); Packaging: TapeAndReel; Part Number: ERJ-3EKF1432V; Part Status: Active; PartId: 26065; Price: 0.01; Ratings: AEC-Q200; Resistance: 14.3 kOhms; Series: ERJ-3EK; Size / Dimension: 0.063\ L x 0.031\ W (1.60mm x 0.80mm); Supplier 1: DigiKey; Supplier Device Package: 0603; Supplier Part Number 1: P14.3KHCT-ND; Tolerance: ±1%; Value: 14.3 kOhms
R71 R72 = ERJ-PA3F1000V: RES SMD 100 OHM 1% 1/3W 0603; Manufacturer: Panasonic Electronic Components; Power (Watts): 0.333W 1/3W; Temperature Coefficient: ±100ppm/°C; Component Kind: Standard; ComponentLink1Description: Datasheet; ComponentLink1URL: https://industrial.panasonic.com/cdbs/www-data/pdf/RDO0000/AOA0000C331.pdf; ComponentLink2Description: DigiKey Link; ComponentLink2URL: https://www.digikey.com/en/products/detail/panasonic-electronic-components/ERJ-PA3F1000V/5035847; Composition: Thick Film; Device Package: 0603 (1608 Metric); Features: Automotive AEC-Q200 Pulse Withstanding; Height - Seated (Max): 0.022\ (0.55mm); LastUpdated: 2024-02-09 20:42:52.130000; Library Name: Altium DB.DbLib; Lifecycle Status: Active; Minimum Order: 1; Number of Terminations: 2; Operating Temperature: -55°C ~ 155°C; Package / Case: 0603 (1608 Metric); Packaging: TapeAndReel; Part Number: ERJ-PA3F1000V; Part Status: Active; PartId: 16197; Price: 0.01; Ratings: AEC-Q200; Resistance: 100 Ohms; Series: ERJ-PA3; Size / Dimension: 0.063\ L x 0.031\ W (1.60mm x 0.80mm); Supplier 1: DigiKey; Supplier Device Package: 0603; Supplier Part Number 1: P100BYCT-ND; Tolerance: ±1%; Value: 100 Ohms
U1 U22 U23 = ADAQ7769-1BBCZ: High Input Impedance, Programmable Gain, 24-Bit, 1MSPS, Alias-Free Î¼ModuleÂ® DAQ Solution; Manufacturer_Name: Analog Devices Inc; Component Kind: Standard; Library Name: Lib.IntLib; Manufacturer_Part_Number: ADAQ7769-1BBCZ; RefDes: RefDes; Type: Type; Copyright: Copyright (C) 2026 Ultra Librarian. All rights reserved.
U2 = AD7415ARTZ-0500RL7: +/-0.5 degrees C Accurate, 10-Bit Digital Temperature Sensor, 2.7 to 5.5 V Supply, Industrial, 5-pin SOT23 (RJ-5), Reel; Manufacturer: Analog Devices; Code_JEDEC: MO-178-AA; Component Kind: Standard; ComponentLink1Description: Manufacturer URL; ComponentLink1URL: http://www.analog.com/en/index.html; ComponentLink2Description: Datasheet; ComponentLink2URL: http://www.analog.com/static/imported-files/data_sheets/AD7414_7415.pdf; ComponentLink3Description: Package Specification; ComponentLink3URL: http://www.analog.com/static/imported-files/packages/PKG_PDF/SOT-23(RJ)/RJ_5.pdf; ComponentLink4Description: Product URL; ComponentLink4URL: http://www.analog.com/en/mems-sensors/digital-temperature-sensors/ad7415/products/product.html; DatasheetVersion: Rev. F; Library Name: Lib.IntLib; Mounting Technology: Surface Mount; PackageDescription: 5-Pin Small Outline Transistor Package, Body 2.9 x 1.6 mm, Pitch 0.95 mm; PackageReference: RJ-5; PackageVersion: 121608-A , 12/2008; Packing: Reel; PartNumber: AD7415ARTZ-0500RL7; Supply Voltage Range: 2.7 to 5.5 V
U8 U11 U15 U17 = ADCMP600BKSZ-R2: Rail-to-Rail, Very Fast, 2.5 V to 5.5 V, Single-Supply TTL/CMOS Comparator in 5-lead SC70 and SOT-23 Packages; Manufacturer_Name: Analog Devices Inc; Component Kind: Standard; Datasheet: https://www.analog.com/media/en/technical-documentation/data-sheets/ADCMP600_601_602.pdf; Library Name: Lib.IntLib; Manufacturer_Part_Number: ADCMP600BKSZ-R2; RefDes: RefDes; Type: Type; Copyright: Copyright (C) 2026 Ultra Librarian. All rights reserved.
U9 U13 = ADG6412BCPZ-REEL7: 0.5 mR RON, ±20 V, +36 V, Quad SPST Switch; Manufacturer_Name: Analog Devices Inc; Component Kind: Standard; Library Name: Lib.IntLib; Manufacturer_Part_Number: ADG6412BCPZ-REEL7; RefDes: RefDes; Type: Interface; Copyright: Copyright (C) 2026 Ultra Librarian. All rights reserved.
U10 U14 U16 = AD8411AWBRMZ: 2 V to 70 V Wide Input Voltage Range, 2.7 MHz High Bandwidth, Current-Sense Amplifier with PWM Rejection and Gain 50 V/V; Manufacturer_Name: Analog Devices Inc; Component Kind: Standard; Library Name: Lib.IntLib; Manufacturer_Part_Number: AD8411AWBRMZ; RefDes: RefDes; Type: Linear; Copyright: Copyright (C) 2026 Ultra Librarian. All rights reserved.
U12 = CMP-04903-000067-1: IC GATE NOR 4CH 2-INP 14TSSOP; Component Kind: Standard; Library Name: Altium Content Vault
U18 = CMP-09137-000153-1: IC VREF SERIES 0.02% 8SOIC; Min Output Voltage: 4.096V; Operating Supply Current: 950uA; Output Current: 10mA; Output Type: Fixed; Temperature Coefficient: 1ppm/°C; Case/Package: SOIC; Component Kind: Standard; Library Name: Altium Content Vault; Max Operating Temperature: 70°C; Min Operating Temperature: 0°C; Mount: Surface Mount; Reference Type: Series; RoHS Compliant: Yes; Series: -; Tolerance: 0.02%
U19 = ADA4051-1AKSZ-R7: Single Channel Rail-to-Rail Operational Amplifier, 125 KHz BW, 60 mV/us SR, Industrial, 5-pin SOT23 (KS-5), Reel; Manufacturer: Analog Devices; Amplifiers Per Package: 1; Input Bias Current: 20pA; Input Offset Voltage: 2uV; Iq/Amp: 15uA; Small Signal Bandwidth: 125KHz; V Span Max: 5.5V; V Span Min: 1.8V; Code_JEDEC: MO-203-AA; Component Kind: Standard; ComponentLink1Description: Manufacturer URL; ComponentLink1URL: http://www.analog.com/en/index.html; ComponentLink2Description: Datasheet; ComponentLink2URL: http://www.analog.com/static/imported-files/data_sheets/ADA4051-1_4051-2.pdf; ComponentLink3Description: Package Specification; ComponentLink3URL: http://www.analog.com/static/imported-files/packages/PKG_PDF/SC70(KS)/KS_5.pdf; DatasheetVersion: Rev. B; Generic Part Number: ADA4051-1; Library Name: Lib.IntLib; Mounting Technology: Surface Mount; PackageDescription: 5-Pin Thin Shrink Small Outline Transistor Package, Body 2 x 1.25 mm, Pitch 0.65 mm; PackageReference: KS-5; PackageVersion: 072809-A; PartNumber: ADA4051-1AKSZ-R7; RevisionNote: Symbol corrected, Pin 5 orientation reversed; Slew Rate: 60mV/us
U20 = CMP-04855-000004-1: IC CLK BUFFER 1:4 250MHZ 8TSSOP; Manufacturer: Texas Instruments; Logic Function: Non-Inverting; Max Junction Temp: +125°C; Max Supply Voltage: 3.6V; Min Supply Voltage: 2.3V; Nominal Supply Current: 3-6mA; Number Of Elements: 1; Number Of Outputs: 4; Propagation Delay: 2ns; Standoff Height: 0.05mm; Ambient Temperature Range High: +85°C; Ambient Temperature Range Low: -40°C; Automotive: No; Category: IC; Component Kind: Standard; ComponentLink2Description: Datasheet; ComponentLink2URL: http://www.ti.com/lit/ds/symlink/cdclvc1104.pdf; Device Class L1: Integrated Circuits (ICs); Device Class L2: Clock and Timing; Device Class L3: Clock Buffers; Digikey Description: IC CLK BUFFER 1:4 250MHZ 8TSSOP; Height: 1.2mm; Lead Free: Yes; Library Name: Altium Content Vault; Manufacturer Part Number: CDCLVC1104PWR; Mouser Description: Clock Buffer Lo Jitter,1:4 LVCMOS Fan-out Clock Buffer; Number Of Inputs: 1; Octopart: https://octopart.com/cdclvc1104pwr-texas+instruments-18629864; Package: PW0008A; RoHS: TRUE; Temperature: -40°C to +85°C; Temperature Range High: +85°C; Temperature Range Low: -40°C
U21 = CMP-09137-000009-1: IC VREF SERIES 0.1% TSOT23-6; Max Input Voltage: 18V; Max Output Voltage: 5V; Min Input Voltage: 5.5V; Number of Channels: 1; Output Current: 5mA; Quiescent Current: 1uA; Temperature Coefficient: 20ppm/°C; Case/Package: SOT-23-6; Component Kind: Standard; Library Name: Altium Content Vault; Max Operating Temperature: 70°C; Min Operating Temperature: 0°C; Mount: Surface Mount; Number of Pins: 6; Output Voltage: 5V; Package Quantity: 1; Packaging: Cut Tape; REACH SVHC: No SVHC; Reference Type: Series; Reference Voltage: 5V; RoHS Compliant: Yes; Series: -; Tolerance: 0.1%
U24 U25 = ADG5204BCPZ-RL7: High Voltage, Latch-up Proof, 4-Channel Multiplexer; Manufacturer_Name: Analog Devices Inc; Component Kind: Standard; Datasheet: https://www.analog.com/media/en/technical-documentation/data-sheets/ADG5204.pdf; Library Name: Lib.IntLib; Manufacturer_Part_Number: ADG5204BCPZ-RL7; RefDes: RefDes; Type: Type; Copyright: Copyright (C) 2026 Ultra Librarian. All rights reserved.
Y1 = CMP-25159-264590-1: Frequency: 16.384000MHz; Frequency Stability: 10ppm; Max Supply Current: 33mA; Case/Package: SMD/SMT; Component Kind: Standard; Description: SiT8208AI-22-33E-16.384000X; Library Name: Altium Content Vault; Max Operating Temperature: 85°C; Min Operating Temperature: -40°C; Mount: Surface Mount; Packaging: Tape and Reel; RoHS Compliant: Yes; Series: SIT8208
